# Altium schematic sheet Sheet2.SchDoc
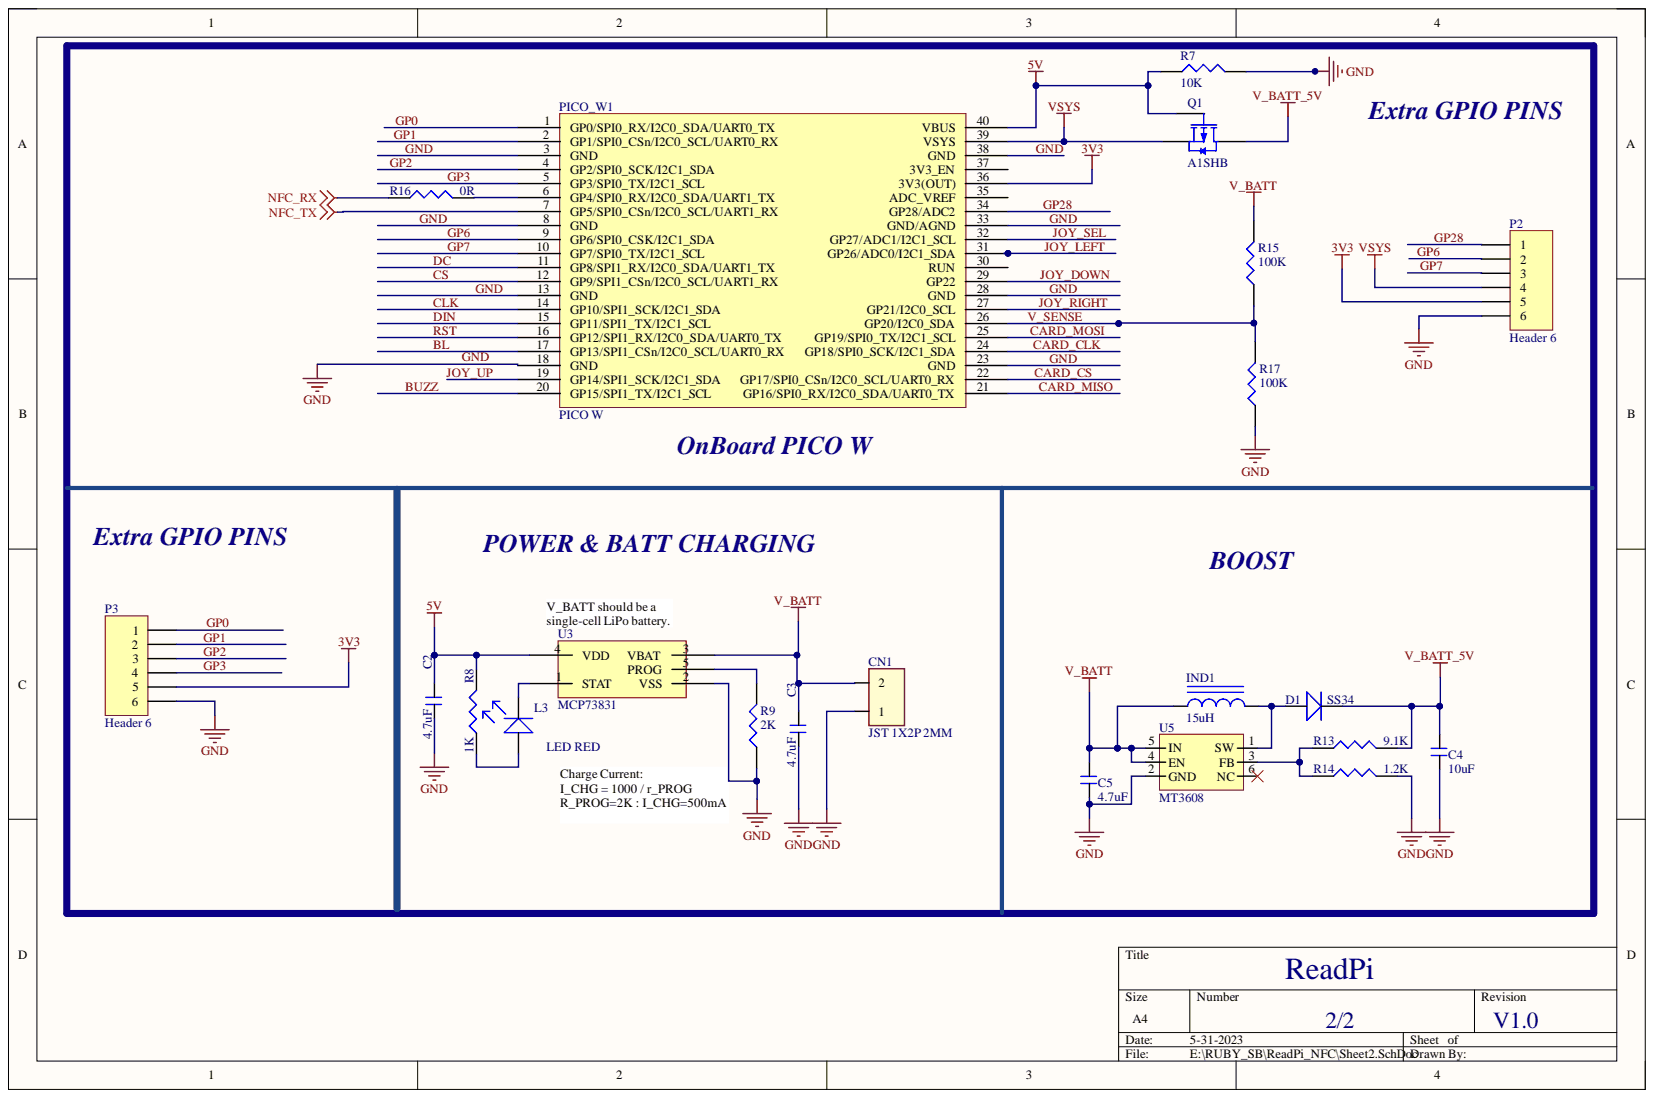
[diagram p0: Sheet2.SchDoc]

## Parts
C2  4.7uF  [CAP_0603_NONPOLAR]
C3  4.7uF  [CAP_0603_NONPOLAR]
C4  10uF  [C_0603]
C5  4.7uF  [C_0603]
CN1  JST 1X2P 2MM  [JST2P_P2.0mm]
D1  SS34  [SS34]
IND1  15uH  [IND 15UH]
L3  LED RED  [LED_0603]
P2  Header 6  [6P_2.54MM]
P3  Header 6  [6P_2.54MM]
PICO_W1  PICO W  [PICOW]
Q1  A1SHB  [BSS84]
R7  10K  [R_0603]
R8  1K  [R_0603]
R9  2K  [R_0603]
R13  9.1K  [R_0603]
R14  1.2K  [R_0603]
R15  100K  [R_0603]
R16  0R  [R_0603]
R17  100K  [R_0603]
U3  MCP73831  [SOT23-5]
U5  MT3608  [SOT23-6]

## Nets
3V3: P2.5 P3.5 PICO_W1.36(3V3(OUT))
5V: C2.1 PICO_W1.40(VBUS) Q1.1 R7.1 R8.2 U3.4(VDD)
NFC_RX: R16.1
NFC_TX: PICO_W1.7(GP5/SPI0_CSn/I2C0_SCL/UART1_RX)
V_BATT: C3.1 C5.1 CN1.2 IND1.1 R15.2 U3.3(VBAT) U5.4(EN) U5.5(IN)
V_BATT_5V: C4.1 D1.K Q1.3 R13.2
VSYS: P2.4 PICO_W1.39(VSYS) Q1.2
BL: PICO_W1.17(GP13/SPI1_CSn/I2C0_SCL/UART0_RX)
BUZZ: PICO_W1.20(GP15/SPI1_TX/I2C1_SCL)
CARD_CLK: PICO_W1.24(GP18/SPI0_SCK/I2C1_SDA)
CARD_CS: PICO_W1.22(GP17/SPI0_CSn/I2C0_SCL/UART0_RX)
CARD_MISO: PICO_W1.21(GP16/SPI0_RX/I2C0_SDA/UART0_TX)
CARD_MOSI: PICO_W1.25(GP19/SPI0_TX/I2C1_SCL)
CLK: PICO_W1.14(GP10/SPI1_SCK/I2C1_SDA)
CS: PICO_W1.12(GP9/SPI1_CSn/I2C0_SCL/UART1_RX)
DC: PICO_W1.11(GP8/SPI1_RX/I2C0_SDA/UART1_TX)
DIN: PICO_W1.15(GP11/SPI1_TX/I2C1_SCL)
GND: C2.2 C3.2 C4.2 C5.2 CN1.1 P2.6 P3.6 PICO_W1.3(GND) PICO_W1.8(GND) PICO_W1.13(GND) PICO_W1.18(GND) PICO_W1.23(GND) PICO_W1.28(GND) PICO_W1.33(GND/AGND) PICO_W1.38(GND) R7.2 R9.1 R14.2 R17.1 U3.2(VSS) U5.2(GND)
GP0: P3.1 PICO_W1.1(GP0/SPI0_RX/I2C0_SDA/UART0_TX)
GP1: P3.2 PICO_W1.2(GP1/SPI0_CSn/I2C0_SCL/UART0_RX)
GP2: P3.3 PICO_W1.4(GP2/SPI0_SCK/I2C1_SDA)
GP3: P3.4 PICO_W1.5(GP3/SPI0_TX/I2C1_SCL)
GP6: P2.2 PICO_W1.9(GP6/SPI0_CSK/I2C1_SDA)
GP7: P2.3 PICO_W1.10(GP7/SPI0_TX/I2C1_SCL)
GP28: P2.1 PICO_W1.34(GP28/ADC2)
JOY_DOWN: PICO_W1.29(GP22)
JOY_LEFT: PICO_W1.31(GP26/ADC0/I2C1_SDA)
JOY_RIGHT: PICO_W1.27(GP21/I2C0_SCL)
JOY_SEL: PICO_W1.32(GP27/ADC1/I2C1_SCL)
JOY_UP: PICO_W1.19(GP14/SPI1_SCK/I2C1_SDA)
RST: PICO_W1.16(GP12/SPI1_RX/I2C0_SDA/UART0_TX)
V_SENSE: PICO_W1.26(GP20/I2C0_SDA) R15.1 R17.2
NetD1_A: D1.A IND1.2 U5.1(SW)
NetL3_A: L3.A R8.1
NetL3_K: L3.K U3.1(STAT)
NetPICO_W1_6: PICO_W1.6(GP4/SPI0_RX/I2C0_SDA/UART1_TX) R16.2
NetR9_2: R9.2 U3.5(PROG)
NetR13_1: R13.1 R14.1 U5.3(FB)

Not connected: PICO_W1 30(RUN) 35(ADC_VREF) 37(3V3_EN); U5 6(NC)

## Library data of the parts
C2-C5 = CAP_NONPOLAR: Component Kind: Standard; Library Name: dxp_pasl_lib.IntLib
CN1 = CON2: Component Kind: Standard; Library Name: dxp_pasl_lib.IntLib
D1 = DIODE: Component Kind: Standard; Library Name: dxp_pasl_lib.IntLib
IND1 = INDUCTOR: Component Kind: Standard; Library Name: dxp_pasl_lib.IntLib
L3 = LED: Component Kind: Standard; Library Name: dxp_pasl_lib.IntLib
P2 P3 = Header 6: Header, 6-Pin; Component Kind: Standard; LatestRevisionDate: 17-Jul-2002; LatestRevisionNote: Re-released for DXP Platform.; Library Name: Miscellaneous Connectors.IntLib; Signal Integrity: Connector; Publisher: Altium Limited
PICO_W1 = CON40_PICO: Component Kind: Standard; Library Name: dxp_pasl_lib.IntLib
Q1 = BSS84: 50V 130mA 250mW 10Ω 10V,130mA 2V 1mA P Channel SOT-23(SOT-23-3) MOSFETs ROHS #C493579; PackageDocument: 1995/1996; CATEGORY: MOSFET; Component Kind: Standard; LatestRevisionDate: 17-Jul-2002; LatestRevisionNote: Re-released for DXP Platform.; PackageReference: E3; Published: 8-Jun-2000; Library Name: dxp_pasl_lib.IntLib; Publisher: Altium Limited
R7-R9 R13-R17 = RES: Component Kind: Standard; Library Name: dxp_pasl_lib.IntLib
U5 = MT3608: 28V~28V 2V~24V 1.2MHz SOT-23-6 DC-DC Converters ROHS #C84817; Component Kind: Standard; Library Name: dxp_pasl_lib.IntLib
U3 = MCP73831: Component Kind: Standard; Library Name: dxp_pasl_lib.IntLib
